# Altium schematic sheet voltage regulators2.SchDoc
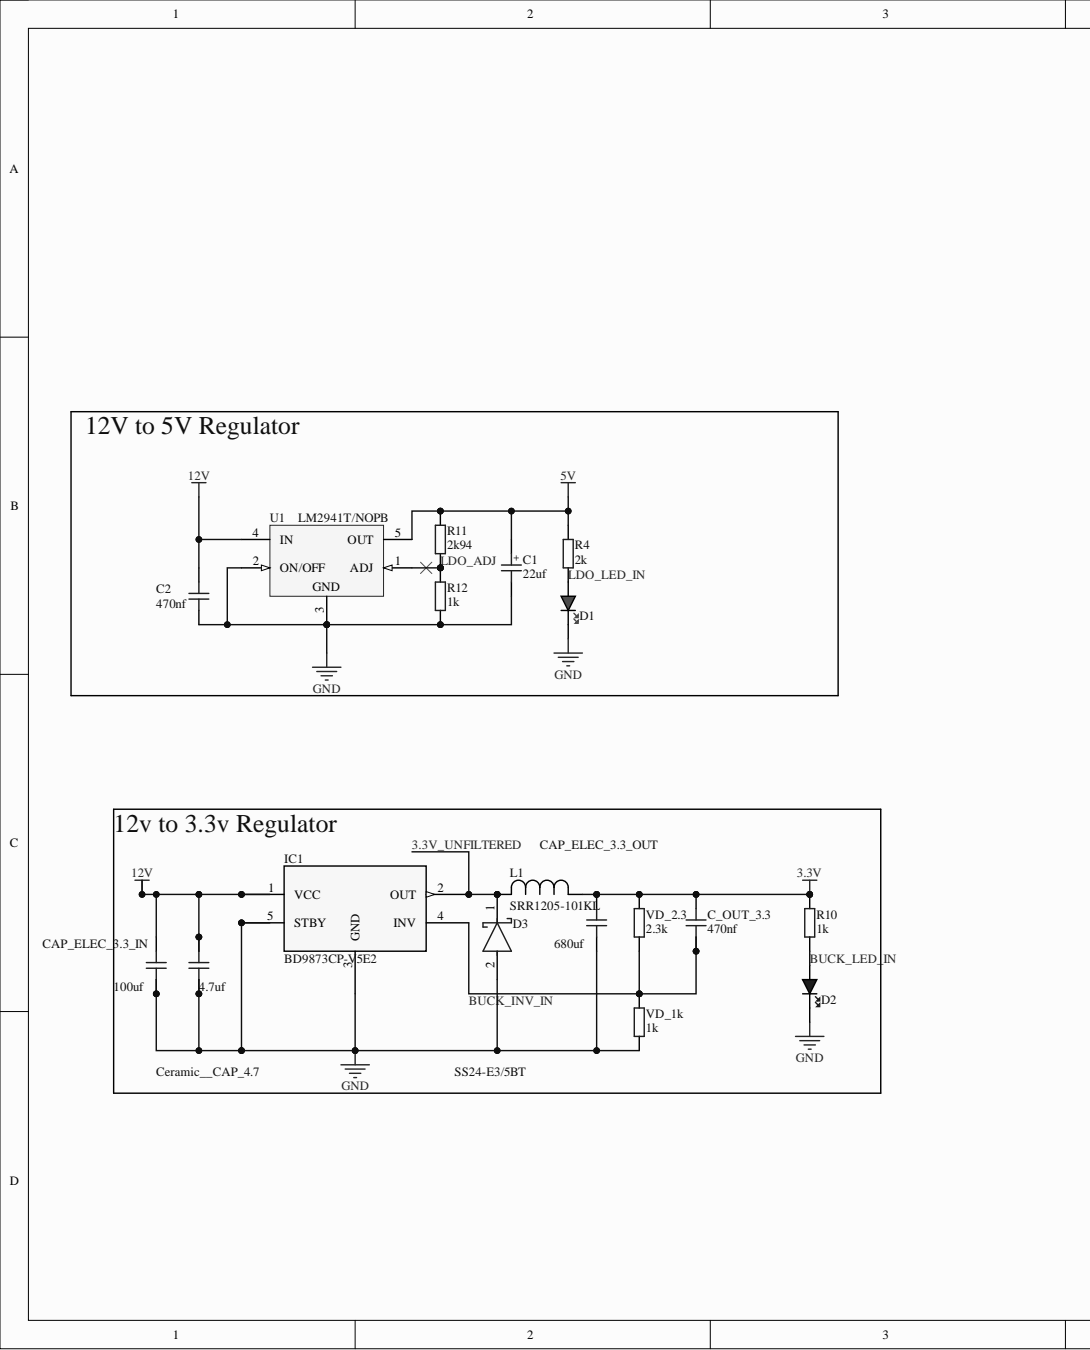
[diagram p0: voltage regulators2.SchDoc, left]
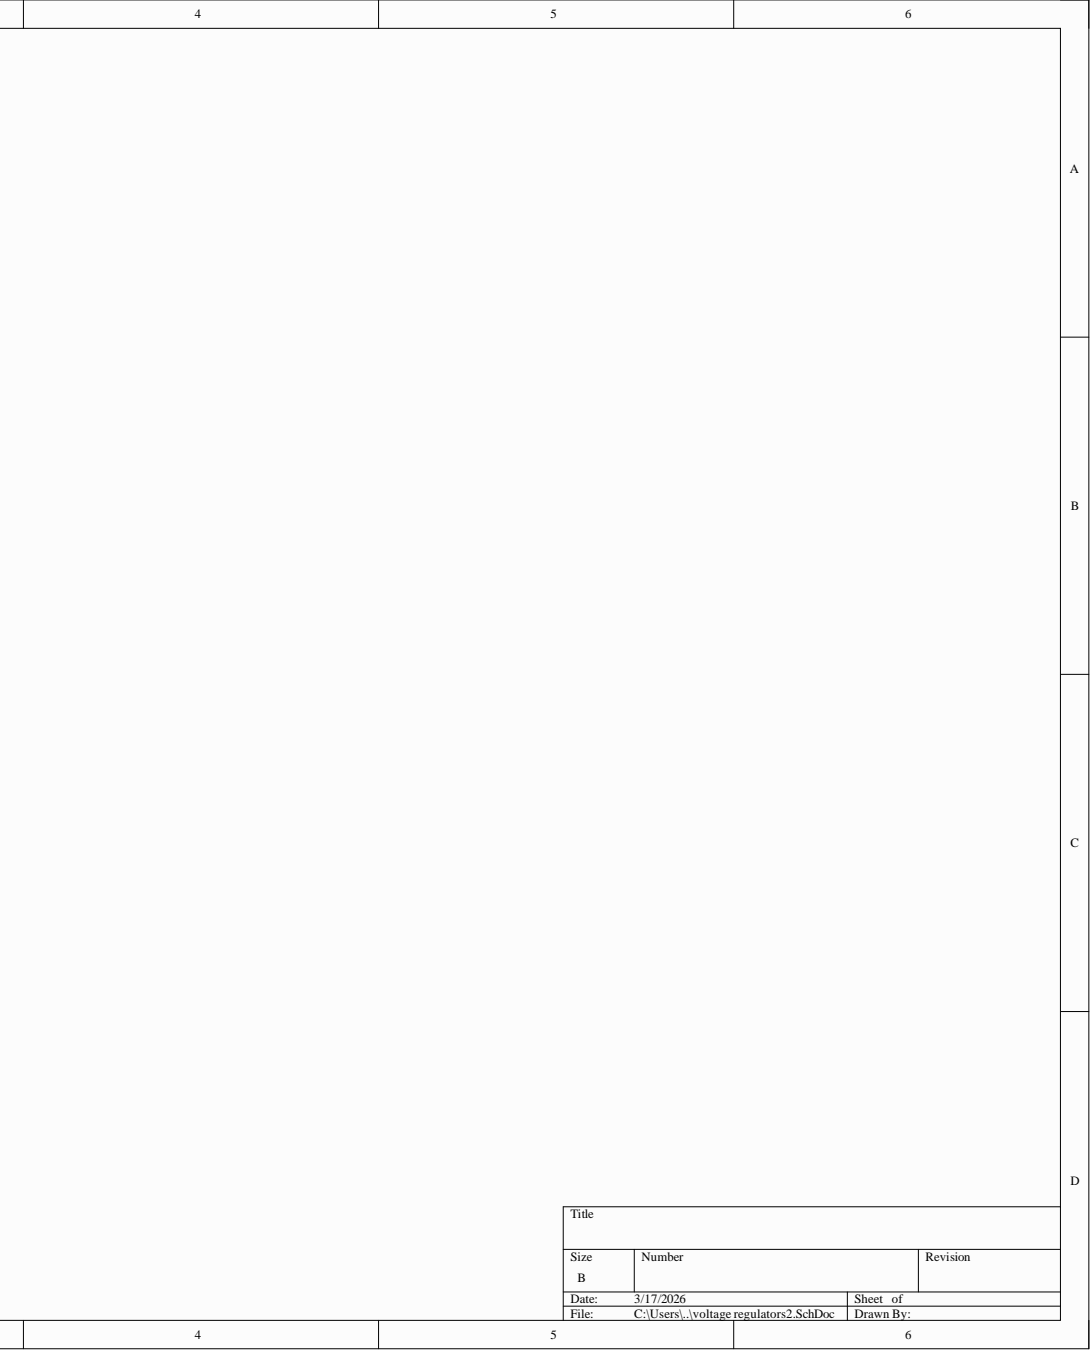
[diagram p1: voltage regulators2.SchDoc, right]

## Parts
C1  22uf  [CAP_TANT_0603]
C2  470nf  [CAP_0402]
C_OUT_3.3  470nf  [CAP_0402]
CAP_ELEC_3.3_IN  100uf  [CAP-EL-NP-5MM]
CAP_ELEC_3.3_OUT  680uf  [CAP-EL-NP-5MM]
Ceramic__CAP_4.7  4.7uf  [CAP_0603]
D1  RED  [LED_0402_RED]  (value not drawn)
D2  BLUE  [LED_0402_BLUE]  (value not drawn)
D3  SS24-E3/5BT  [FP-95401-MFG]
IC1  BD9873CP-V5E2  [TO220CP-V5_ROM]
L1  SRR1205-101KL  [IND_BOURNS_SRR1205]
R4  2k  [RES_0402]
R10  1k  [RES_0402]
R11  2k94  [RES_0402]
R12  1k  [RES_0402]
U1  LM2941T/NOPB  [KC0005A]
VD_1k  1k  [RES_0402]
VD_2.3  2.3k  [RES_0402]

## Nets
3.3V: C_OUT_3.3.2 CAP_ELEC_3.3_OUT.2 L1.1 R10.2 VD_2.3.2
5V: C1. R4.2 R11.2 U1.5(OUT)
12V: C2.2 CAP_ELEC_3.3_IN.2 Ceramic__CAP_4.7.2 IC1.1(VCC) U1.4(IN)
GND: C1. C2.1 CAP_ELEC_3.3_IN.1 CAP_ELEC_3.3_OUT.1 Ceramic__CAP_4.7.1 D1. D2.K D3.2 IC1.3(GND) IC1.5(STBY) R12.1 U1.2(ON/OFF) U1.3 VD_1k.1
3.3V_UNFILTERED: D3.1 IC1.2(OUT) L1.2
BUCK_INV_IN: C_OUT_3.3.1 IC1.4(INV) VD_1k.2 VD_2.3.1
BUCK_LED_IN: D2.A R10.1
LDO_ADJ: R11.1 R12.2 U1.1(ADJ)
LDO_LED_IN: D1.A R4.1

## Library data of the parts
C1 = CAP_TANT_0603: Capacitor SMD Tantalum 0603; Component Kind: Standard; Library Name: Schematic-Lib-PhilsLab.SchLib
C2 C_OUT_3.3 = CAP_0402: Capacitor SMD 0402; Component Kind: Standard; Library Name: Schematic-Lib-PhilsLab.SchLib
CAP_ELEC_3.3_IN CAP_ELEC_3.3_OUT = CAP_EL_NP_5MM: Capacitor SMD Electrolytic Non-Polarised 5mm Diameter; Manufacturer: Nichicon; Component Kind: Standard; Library Name: Schematic-Lib-PhilsLab.SchLib
Ceramic__CAP_4.7 = CAP_0603: Capacitor SMD 0603; Component Kind: Standard; Library Name: Schematic-Lib-PhilsLab.SchLib
D1 = LED_0402_RED: LED 0402 RED SMD; Component Kind: Standard; Library Name: Schematic-Lib-PhilsLab.SchLib
D2 = LED_0402_BLUE: LED 0402 BLUE SMD; Component Kind: Standard; Library Name: Schematic-Lib-PhilsLab.SchLib
D3 = CMP-00030-42277690-1: SS24-E3/5BT Rectifier Diode, Schottky, 1 Phase, 1 Element, 2A, 40V V(RRM), Silicon, DO-214AA; Element Configuration: Single; Forward Voltage: 500mV; Max Forward Surge Current (Ifsm): 75A; Max Reverse Leakage Current: 400µA; Average Rectified Current: 2A; Case/Package: SMB; Component Kind: Standard; Contact Plating: Tin; Forward Current: 2A; Lead Free: Lead Free; Length: 4.7mm; Library Name: Altium Content Vault; Max Operating Temperature: 125°C; Max Repetitive Reverse Voltage (Vrrm): 40V; Max Reverse Voltage (DC): 40V; Max Surge Current: 75A; Min Operating Temperature: -65°C; Mount: Surface Mount; NexarPartId: 42277690; Number of Pins: 2; Peak Non-Repetitive Surge Current: 75A; Peak Reverse Current: 400µA; Radiation Hardening: No; RoHS: Compliant; Width: 3.8mm
IC1 = BD9873CP-V5E2: No Description Available; Manufacturer_Name: ROHM Semiconductor; Component Kind: Standard; Library Name: BD9873CP-V5E2.SchLib; Manufacturer_Part_Number: BD9873CP-V5E2; RefDes: RefDes; Type: Type; Copyright: Copyright (C) 2025 Ultra Librarian. All rights reserved.
L1 = SRR1205-101KL: No Description Available; Manufacturer_Name: Bourns Electronics; Component Kind: Standard; Library Name: SRR1205-101KL.SchLib; Manufacturer_Part_Number: SRR1205-101KL; Copyright: Copyright (C) 2026 Ultra Librarian. All rights reserved.
R4 R10-R12 VD_1k VD_2.3 = RES_0402: Resistor SMD 0402; Component Kind: Standard; Library Name: Schematic-Lib-PhilsLab.SchLib
U1 = CMP-0062-02559-3: 1A Low Dropout Adjustable Regulator, 5-pin TO-220, Pb-Free; Manufacturer: Texas Instruments; CompType: LDO IC; PackageTopMarking: NSUZXYTTE#*LM2941T*P+; PowerWise: N; Code_JEDEC: TO-220-AB; Component Kind: Standard; ComponentLink1Description: Datasheet URL; ComponentLink1URL: http://www.ti.com/general/docs/lit/getliterature.tsp?genericPartNumber=LM2941&fileType=pdf; Library Name: Altium Content Vault; PackageDescription: TO-220, Molded, 5 Lead, Straight; PackageReference: KC0005A; PinCount: 5; RoHS: Y
